# Altium schematic sheet SHIELD_TRF7960A.SchDoc(SHIELD_TRF7960A)
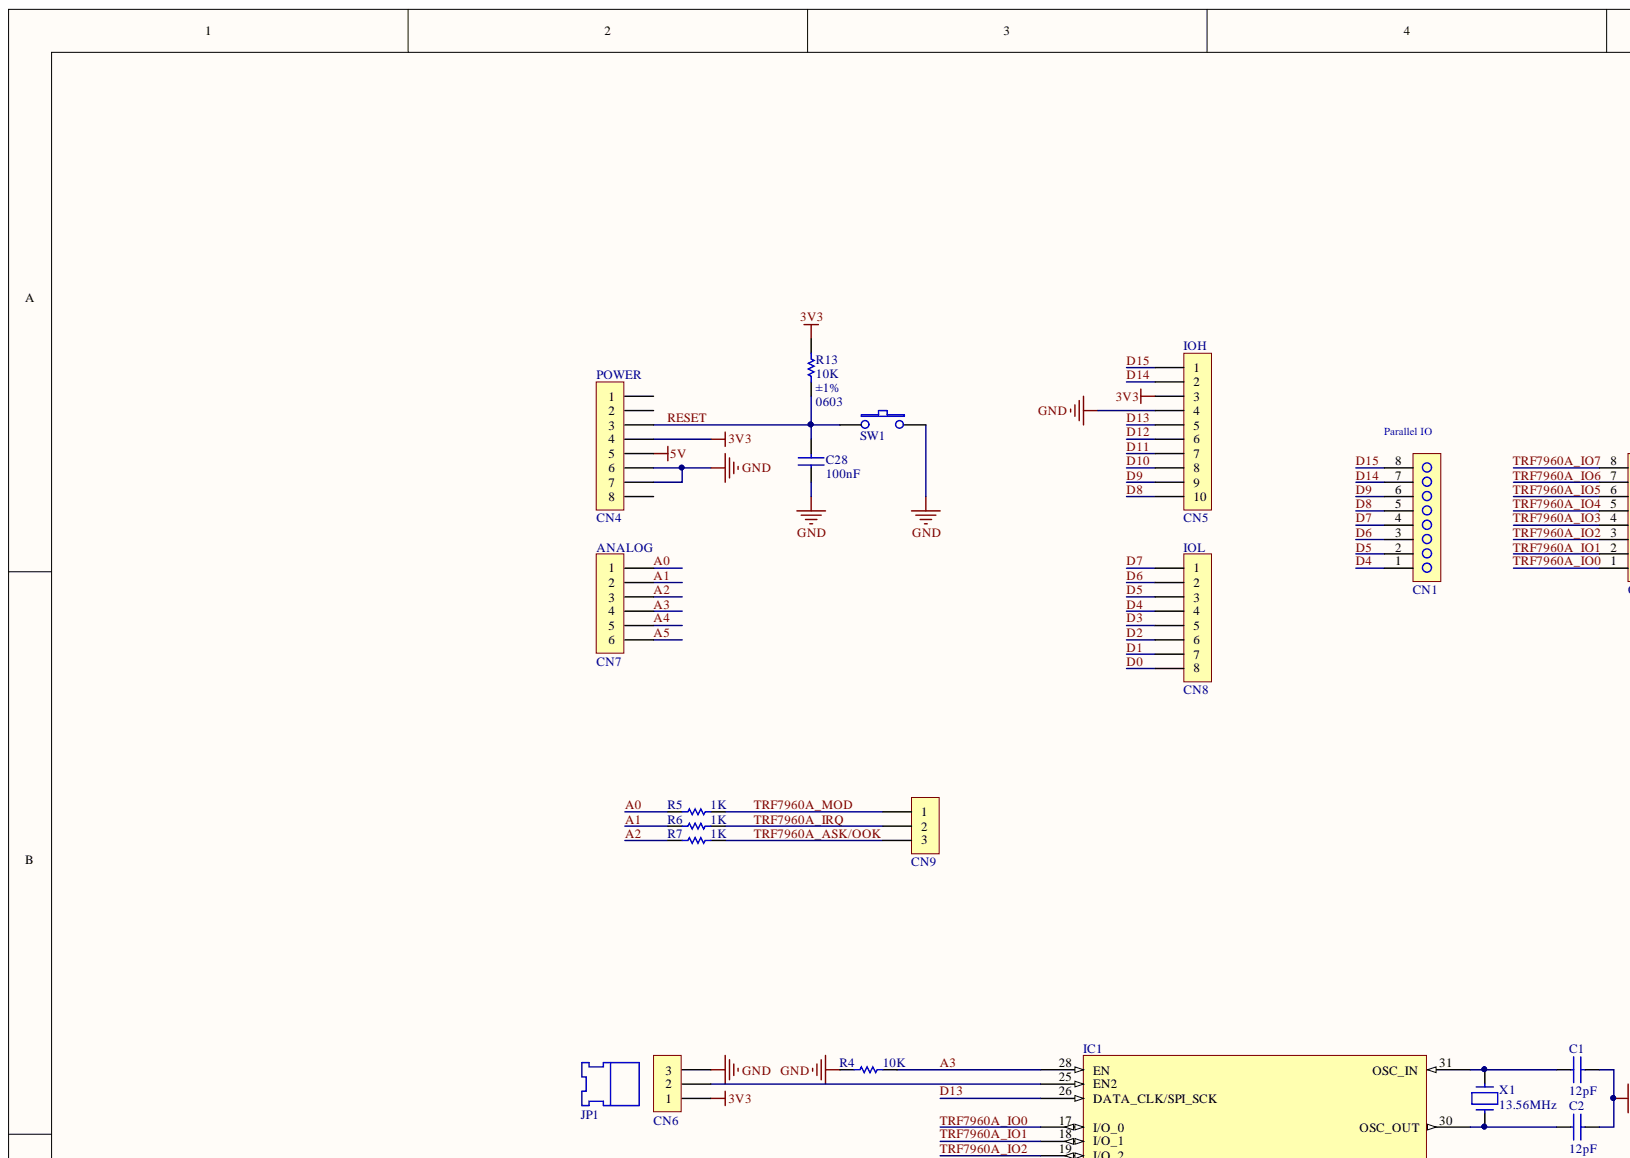
[diagram p0: SHIELD_TRF7960A.SchDoc, top left]
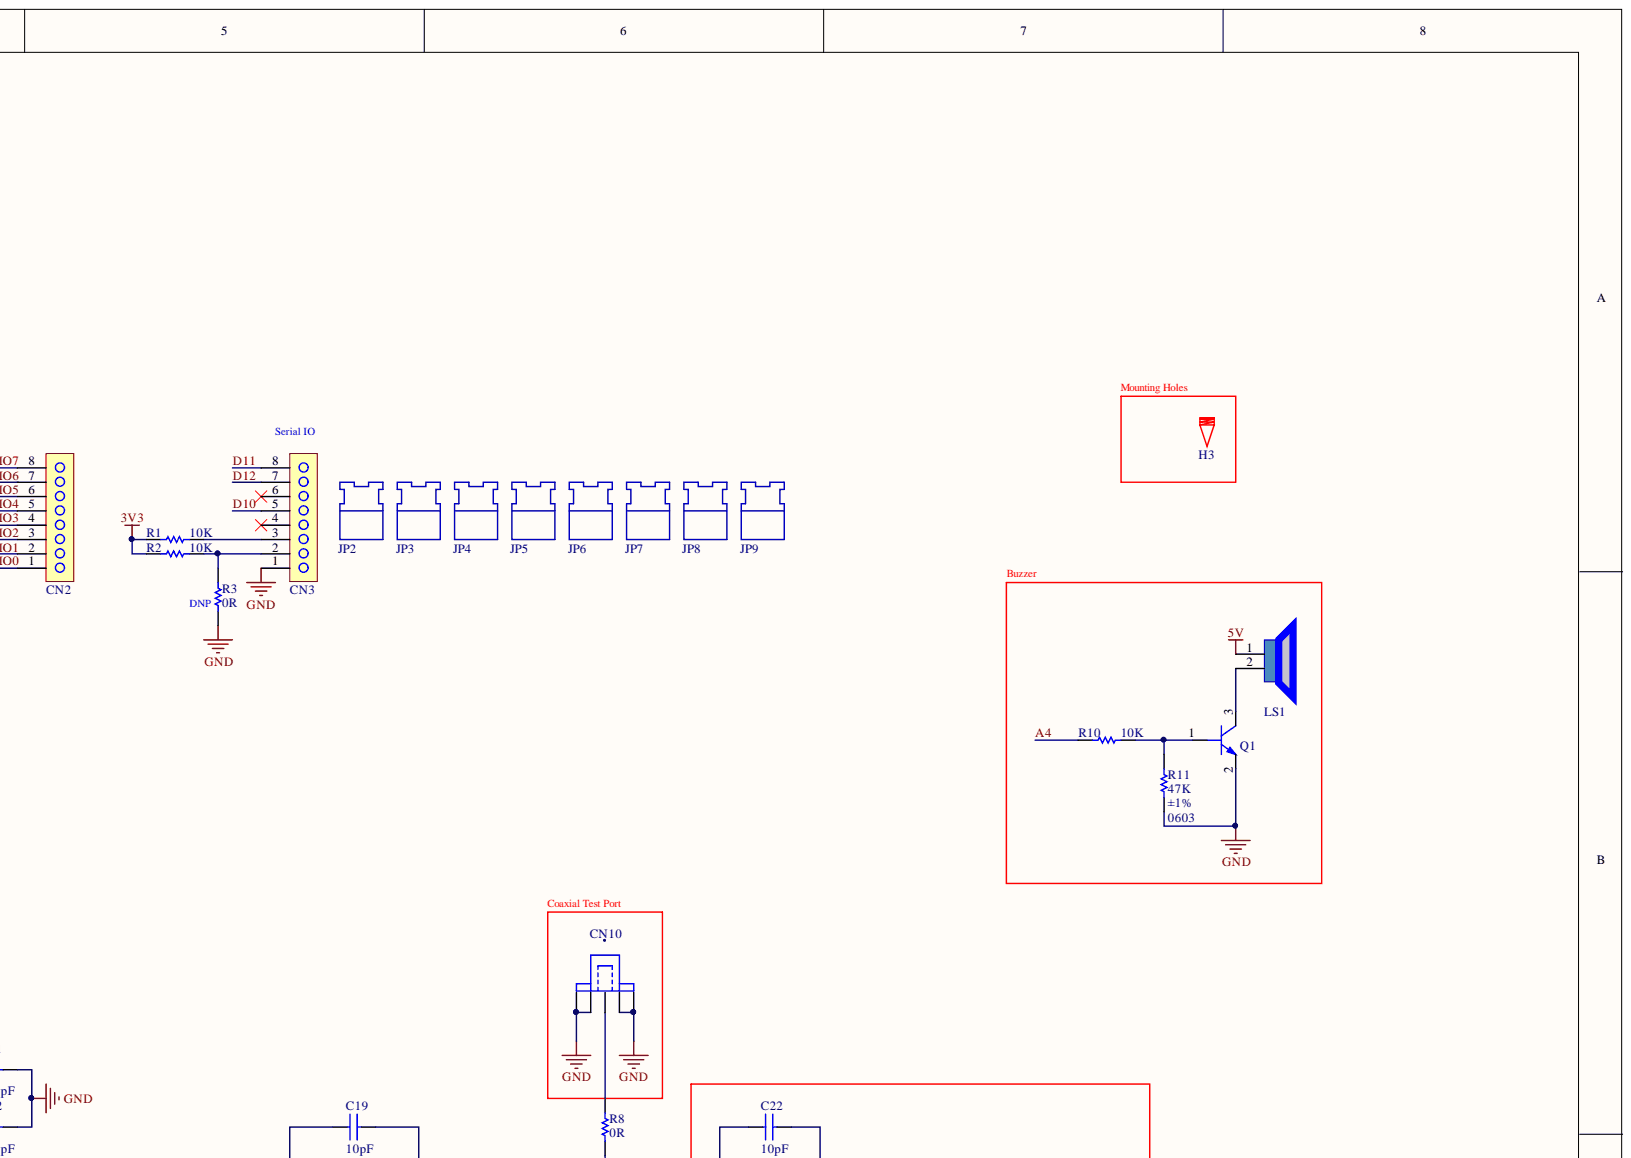
[diagram p1: SHIELD_TRF7960A.SchDoc, top right]
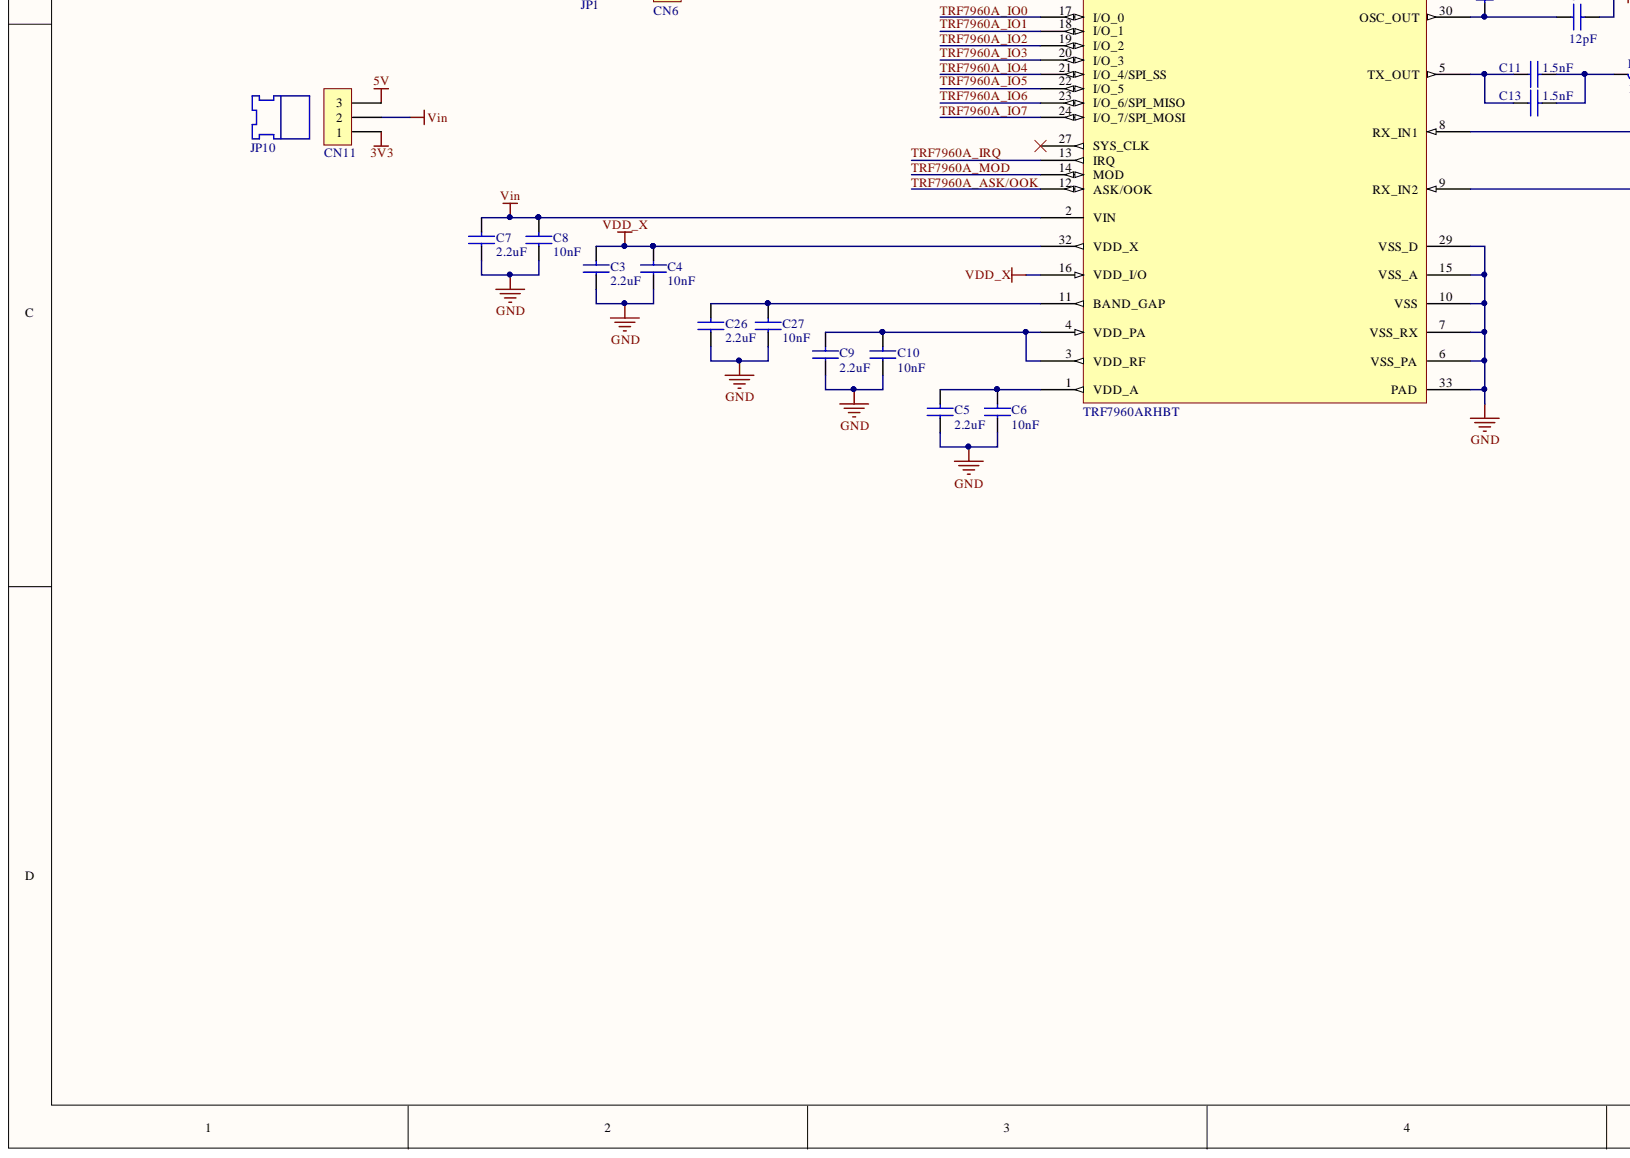
[diagram p2: SHIELD_TRF7960A.SchDoc, bottom left]
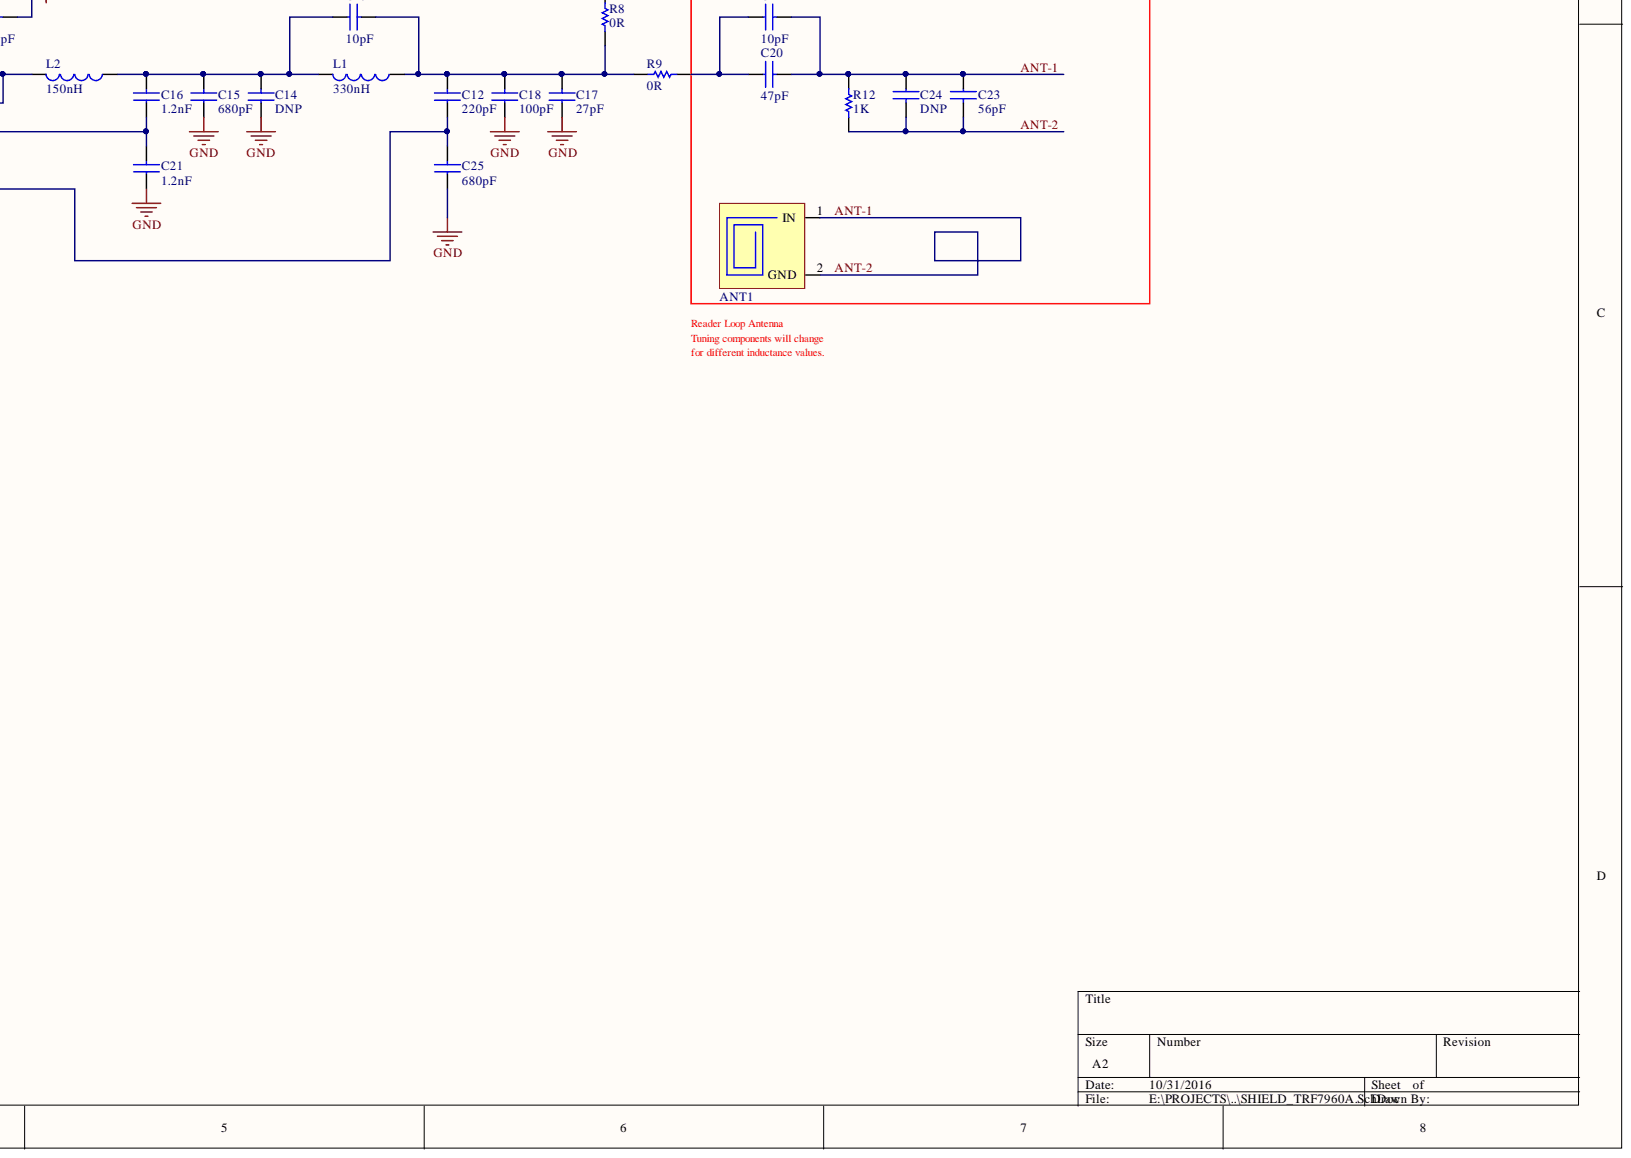
[diagram p3: SHIELD_TRF7960A.SchDoc, bottom right]

## Parts
ANT1  ANTENNA_LOOP  [ANTENNA_LOOP]  (value not drawn)
C1  value 12pF  Capacitance: 12pF  [CAPC1608X90N_EIA0603]  (hidden comment: CAP_NP)
C2  value 12pF  Capacitance: 12pF  [CAPC1608X90N_EIA0603]  (hidden comment: CAP_NP)
C3  value 2.2uF  [CAPC1608X90N_EIA0603]  (hidden comment: CAP_NP)
C4  value 10nF  Capacitance: 10nF  [CAPC1608X90N_EIA0603]  (hidden comment: CAP_NP)
C5  value 2.2uF  [CAPC1608X90N_EIA0603]  (hidden comment: CAP_NP)
C6  value 10nF  Capacitance: 10nF  [CAPC1608X90N_EIA0603]  (hidden comment: CAP_NP)
C7  value 2.2uF  [CAPC1608X90N_EIA0603]  (hidden comment: CAP_NP)
C8  value 10nF  Capacitance: 10nF  [CAPC1608X90N_EIA0603]  (hidden comment: CAP_NP)
C9  value 2.2uF  [CAPC1608X90N_EIA0603]  (hidden comment: CAP_NP)
C10  value 10nF  Capacitance: 10nF  [CAPC1608X90N_EIA0603]  (hidden comment: CAP_NP)
C11  value 1.5nF  Capacitance: 1.5nF  [CAPC1608X90N_EIA0603]  (hidden comment: CAP_NP)
C12  value 220pF  Capacitance: 220pF  [CAPC1608X90N_EIA0603]  (hidden comment: CAP_NP)
C13  value 1.5nF  Capacitance: 1.5nF  [CAPC1608X90N_EIA0603]  (hidden comment: CAP_NP)
C14  value DNP  Capacitance: DNP  [CAPC1608X90N_EIA0603]  (hidden comment: CAP_NP)
C15  value 680pF  Capacitance: 680pF  [CAPC1608X90N_EIA0603]  (hidden comment: CAP_NP)
C16  value 1.2nF  Capacitance: 1.2nF  [CAPC1608X90N_EIA0603]  (hidden comment: CAP_NP)
C17  value 27pF  Capacitance: 27pF  [CAPC1608X90N_EIA0603]  (hidden comment: CAP_NP)
C18  value 100pF  Capacitance: 100pF  [CAPC1608X90N_EIA0603]  (hidden comment: CAP_NP)
C19  value 10pF  Capacitance: 10pF  [CAPC1608X90N_EIA0603]  (hidden comment: CAP_NP)
C20  value 47pF  Capacitance: 47pF  [CAPC1608X90N_EIA0603]  (hidden comment: CAP_NP)
C21  value 1.2nF  Capacitance: 1.2nF  [CAPC1608X90N_EIA0603]  (hidden comment: CAP_NP)
C22  value 10pF  Capacitance: 10pF  [CAPC1608X90N_EIA0603]  (hidden comment: CAP_NP)
C23  value 56pF  Capacitance: 56pF  [CAPC1608X90N_EIA0603]  (hidden comment: CAP_NP)
C24  value DNP  Capacitance: DNP  [CAPC1608X90N_EIA0603]  (hidden comment: CAP_NP)
C25  value 680pF  Capacitance: 680pF  [CAPC1608X90N_EIA0603]  (hidden comment: CAP_NP)
C26  value 2.2uF  [CAPC1608X90N_EIA0603]  (hidden comment: CAP_NP)
C27  value 10nF  Capacitance: 10nF  [CAPC1608X90N_EIA0603]  (hidden comment: CAP_NP)
C28  value 100nF  Capacitance: 100nF  [CAPC1608X90N_EIA0603]  (hidden comment: CAP_NP)
CN1  HDRV_1X8  [HDRV8W64P254_1X8_2032X254X600P]  (value not drawn)
CN2  HDRV_1X8  [HDRV8W64P254_1X8_2032X254X600P]  (value not drawn)
CN3  HDRV_1X8  [HDRV8W64P254_1X8_2032X254X600P]  (value not drawn)
CN4  HDR_1X8  [HDRFV8W70P254_1X8_2032X254X1118P]  (value not drawn)
CN5  HDR_1X10  [HDRFV10W70P254_1X10_2540X254X1118P]  (value not drawn)
CN6  HDR_1X3  [HDRV3W64P254_1X3_762X254X600P]  (value not drawn)
CN7  HDR_1X6  [HDRFV6W70P254_1X6_1524X254X1118P]  (value not drawn)
CN8  HDR_1X8  [HDRFV8W70P254_1X8_2032X254X1118P]  (value not drawn)
CN9  HDR_1X3  [HDRV3W64P254_1X3_762X254X600P]  (value not drawn)
CN10  SMA  [EMERSON_142-0701-201]  (value not drawn)
CN11  HDR_1X3  [HDRV3W64P254_1X3_762X254X600P]  (value not drawn)
H3  HOLES  [HOLE_125MIL]  (value not drawn)
IC1  TRF7960A  Manufacturer Part Number: TRF7960ARHBT  [QFN50P350X500X90_HS-33N]
JP1  JUMPER  [JUMPER254P]  (value not drawn)
JP2  JUMPER  [JUMPER254P]  (value not drawn)
JP3  JUMPER  [JUMPER254P]  (value not drawn)
JP4  JUMPER  [JUMPER254P]  (value not drawn)
JP5  JUMPER  [JUMPER254P]  (value not drawn)
JP6  JUMPER  [JUMPER254P]  (value not drawn)
JP7  JUMPER  [JUMPER254P]  (value not drawn)
JP8  JUMPER  [JUMPER254P]  (value not drawn)
JP9  JUMPER  [JUMPER254P]  (value not drawn)
JP10  JUMPER  [JUMPER254P]  (value not drawn)
L1  value 330nH  Inductance: 330nH  [INDC2115X13N_EIA0805]  (hidden comment: INDUCTOR)
L2  value 150nH  Inductance: 150nH  [INDC2115X13N_EIA0805]  (hidden comment: INDUCTOR)
LS1  BUZZER  [BUZZER_12MM]  (value not drawn)
Q1  BC817  [SOT95P230X110-3N_SOT-23]  (value not drawn)
R1  value 10K  Resistance (Ohm): 10K  [RESC1608X50N_EIA0603]  (hidden comment: RES)
R2  value 10K  Resistance (Ohm): 10K  [RESC1608X50N_EIA0603]  (hidden comment: RES)
R3  value 0R  [RESC1608X50N_EIA0603]  (hidden comment: RES)
R4  value 10K  Resistance (Ohm): 10K  [RESC1608X50N_EIA0603]  (hidden comment: RES)
R5  value 1K  Resistance (Ohm): 1K  [RESC1608X50N_EIA0603]  (hidden comment: RES)
R6  value 1K  Resistance (Ohm): 1K  [RESC1608X50N_EIA0603]  (hidden comment: RES)
R7  value 1K  Resistance (Ohm): 1K  [RESC1608X50N_EIA0603]  (hidden comment: RES)
R8  value 0R  [RESC1608X50N_EIA0603]  (hidden comment: RES)
R9  value 0R  [RESC1608X50N_EIA0603]  (hidden comment: RES)
R10  value 10K  Resistance (Ohm): 10K  [RESC1608X50N_EIA0603]  (hidden comment: RES)
R11  value 47K  Package / Case: 0603  Resistance (Ohm): 47K  Tolerance: ±1%  [RESC1608X50N_EIA0603]  (hidden comment: RES)
R12  value 1K  Resistance (Ohm): 1K  [RESC1608X50N_EIA0603]  (hidden comment: RES)
R13  value 10K  Package / Case: 0603  Resistance (Ohm): 10K  Tolerance: ±1%  [RESC1608X50N_EIA0603]  (hidden comment: RES)
SW1  SWITCH_TACTILE_2PIN  [C&K_RS-282G05A3-SM_RT]  (value not drawn)
X1  value 13.56MHz  [HC-49/US]  (hidden comment: CRYSTAL)

## Nets
3V3: CN4.4 CN5.3 CN6.1 CN11.1 R1.1 R2.1 R13.1
5V: CN4.5 CN11.3 LS1.1
GND: C1.2 C2.2 C3.1 C4.1 C5.1 C6.1 C7.1 C8.1 C9.1 C10.1 C14.1 C15.1 C17.1 C18.1 C21.1 C25.1 C26.1 C27.1 C28.1 CN3.1 CN4.6 CN4.7 CN5.4 CN6.3 CN10.2 CN10.3 CN10.4 CN10.5 IC1.6(VSS_PA) IC1.7(VSS_RX) IC1.10(VSS) IC1.15(VSS_A) IC1.29(VSS_D) IC1.33(PAD) Q1.2 R3.2 R4.1 R11.2 SW1.2
VDD_X: C3.2 C4.2 IC1.16(VDD_I/O) IC1.32(VDD_X)
VIN: C7.2 C8.2 CN11.2 IC1.2(VIN)
A0: CN7.1 R5.1
A1: CN7.2 R6.1
A2: CN7.3 R7.1
A3: CN7.4 IC1.28(EN) R4.2
A4: CN7.5 R10.1
A5: CN7.6
ANT-1: ANT1.1 ANT1.2 C20.2 C22.2 C23.1 C23.2 C24.1 C24.2 R12.1 R12.2
D0: CN8.8
D1: CN8.7
D2: CN8.6
D3: CN8.5
D4: CN1.1 CN8.4
D5: CN1.2 CN8.3
D6: CN1.3 CN8.2
D7: CN1.4 CN8.1
D8: CN1.5 CN5.10
D9: CN1.6 CN5.9
D10: CN3.5 CN5.8
D11: CN3.8 CN5.7
D12: CN3.7 CN5.6
D13: CN5.5 IC1.26(DATA_CLK/SPI_SCK)
D14: CN1.7 CN5.2
D15: CN1.8 CN5.1
RESET: C28.2 CN4.3 R13.2 SW1.1
TRF7960A_ASK/OOK: CN9.3 IC1.12(ASK/OOK) R7.2
TRF7960A_IO0: CN2.1 IC1.17(I/O_0)
TRF7960A_IO1: CN2.2 IC1.18(I/O_1)
TRF7960A_IO2: CN2.3 IC1.19(I/O_2)
TRF7960A_IO3: CN2.4 IC1.20(I/O_3)
TRF7960A_IO4: CN2.5 IC1.21(I/O_4/SPI_SS)
TRF7960A_IO5: CN2.6 IC1.22(I/O_5)
TRF7960A_IO6: CN2.7 IC1.23(I/O_6/SPI_MISO)
TRF7960A_IO7: CN2.8 IC1.24(I/O_7/SPI_MOSI)
TRF7960A_IRQ: CN9.2 IC1.13(IRQ) R6.2
TRF7960A_MOD: CN9.1 IC1.14(MOD) R5.2
NetC1_1: C1.1 IC1.31(OSC_IN) X1.1
NetC2_1: C2.1 IC1.30(OSC_OUT) X1.2
NetC5_2: C5.2 C6.2 IC1.1(VDD_A)
NetC9_2: C9.2 C10.2 IC1.3(VDD_RF) IC1.4(VDD_PA)
NetC11_1: C11.1 C13.1 IC1.5(TX_OUT)
NetC11_2: C11.2 C13.2 L2.2
NetC12_1: C12.1 C25.2 IC1.9(RX_IN2)
NetC12_2: C12.2 C17.2 C18.2 C19.2 L1.1 R8.1 R9.1
NetC14_2: C14.2 C15.2 C16.2 C19.1 L1.2 L2.1
NetC16_1: C16.1 C21.2 IC1.8(RX_IN1)
NetC20_1: C20.1 C22.1 R9.2
NetC26_2: C26.2 C27.2 IC1.11(BAND_GAP)
NetCN3_2: CN3.2 R2.2 R3.1
NetCN3_3: CN3.3 R1.2
NetCN6_2: CN6.2 IC1.25(EN2)
NetCN10_1: CN10.1 R8.2
NetLS1_2: LS1.2 Q1.3
NetQ1_1: Q1.1 R10.2 R11.1

Not connected: CN3 4 6; CN4 1 2 8; IC1 27(SYS_CLK)

## Library data of the parts
ANT1 = SMSC00004: ANTENNA LOOP; Database Table Name: Misc; Component Kind: Standard; Library Name: ComponentDatabase.DbLib; Part Number: SMSC00004; Value: ANTENNA
C1 C2 = SCAP00200035: CAP CER 12PF 50V NP0 0603; Manufacturer: Murata Electronics North America; Manufacturer Part Number: GRM1885C1H120JA01D; Cost x1 ($): 0.10000; Cost x10 ($): 0.04100; Cost x100 ($): 0.01870; Cost x1000 ($): 0.01020; Database Table Name: Capacitor; Distrubutor: Digikey; Lead Time: 19 Weeks; Temperature Coefficient: C0G, NP0; Tolerance: ±5%; Type: Multi Layer Ceramic Capacitor  (MLCC); Category: Capacitors; Component Kind: Standard; Distributor Link: http://www.digikey.com/product-detail/en/murata-electronics-north-america/GRM1885C1H120JA01D/490-1405-1-ND/587644; Distributor Part Number: 490-1405-1-ND; Family: Ceramic Capacitors; Library Name: ComponentDatabase.DbLib; Moisture Sensitivity Level (MSL): 1 (Unlimited); Mounting Type: Surface Mount, MLCC; Operating Temperature: -55°C ~ 125°C; Package / Case: 0603; Part Number: SCAP00200035; Quantity: 0; ROHS: YES; Size / Dimension: 0.063" L x 0.031" W (1.60mm x 0.80mm); Voltage - Rated: 50V
C3 C5 C7 C9 C26 = SCAP00200098: CAP CER 2.2UF 6.3V X5R 0603; Manufacturer: Murata Electronics North America; Manufacturer Part Number: GRM188R60J225KE19D; Capacitance: 2.2µF; Cost x1 ($): 0.13000; Cost x10 ($): 0.08800; Cost x100 ($): 0.04180; Cost x1000 ($): 0.02420; Database Table Name: Capacitor; Distrubutor: Digikey; Lead Time: 19 Weeks; Tolerance: ±10%; Type: Multi Layer Ceramic Capacitor  (MLCC); Category: Capacitors; Component Kind: Standard; Distributor Link: http://www.digikey.com/product-detail/en/murata-electronics-north-america/GRM188R60J225KE19D/490-1552-1-ND/587757; Distributor Part Number: 490-1552-1-ND; Family: Ceramic Capacitors; Library Name: ComponentDatabase.DbLib; Moisture Sensitivity Level (MSL): 1 (Unlimited); Mounting Type: Surface Mount, MLCC; Operating Temperature: -55°C ~ 85°C; Package / Case: 0603; Part Number: SCAP00200098; Quantity: 0; ROHS: YES; Size / Dimension: 0.063" L x 0.031" W (1.60mm x 0.80mm); Temperature Coefficient: X5R; Voltage - Rated: 6.3V
C4 C6 C8 C10 C27 = SCAP00200083: CAP CER 10000PF 50V X7R 0603; Manufacturer: Murata Electronics North America; Manufacturer Part Number: GRM188R71H103KA01D; Cost x1 ($): 0.10000; Cost x10 ($): 0.01900; Cost x100 ($): 0.00850; Cost x1000 ($): 0.00465; Database Table Name: Capacitor; Distrubutor: Digikey; Lead Time: 19 Weeks; Tolerance: ±10%; Type: Multi Layer Ceramic Capacitor  (MLCC); Category: Capacitors; Component Kind: Standard; Distributor Link: http://www.digikey.com/product-detail/en/murata-electronics-north-america/GRM188R71H103KA01D/490-1512-1-ND/587862; Distributor Part Number: 490-1512-1-ND; Family: Ceramic Capacitors; Library Name: ComponentDatabase.DbLib; Moisture Sensitivity Level (MSL): 1 (Unlimited); Mounting Type: Surface Mount, MLCC; Operating Temperature: -55°C ~ 125°C; Package / Case: 0603; Part Number: SCAP00200083; Quantity: 0; ROHS: YES; Size / Dimension: 0.063" L x 0.031" W (1.60mm x 0.80mm); Temperature Coefficient: X7R; Voltage - Rated: 50V
C11 C13 = SCAP00200075: CAP CER 1500PF 50V X7R 0603; Manufacturer: Murata Electronics North America; Manufacturer Part Number: GRM188R71H152KA01D; Cost x1 ($): 0.10000; Cost x10 ($): 0.03500; Cost x100 ($): 0.01600; Cost x1000 ($): 0.00873; Database Table Name: Capacitor; Distrubutor: Digikey; Lead Time: 19 Weeks; Tolerance: ±10%; Type: Multi Layer Ceramic Capacitor  (MLCC); Category: Capacitors; Component Kind: Standard; Distributor Link: http://www.digikey.com/product-detail/en/murata-electronics-north-america/GRM188R71H152KA01D/490-1498-1-ND/587878; Distributor Part Number: 490-1498-1-ND; Family: Ceramic Capacitors; Library Name: ComponentDatabase.DbLib; Moisture Sensitivity Level (MSL): 1 (Unlimited); Mounting Type: SMD; Operating Temperature: -55°C ~ 125°C; Package / Case: 0603; Part Number: SCAP00200075; Quantity: 0; ROHS: YES; Size / Dimension: 0.063" L x 0.031" W (1.60mm x 0.80mm); Temperature Coefficient: X7R; Voltage - Rated: 50V
C12 = SCAP00200065: CAP CER 220PF 50V NP0 0603; Manufacturer: Murata Electronics North America; Manufacturer Part Number: GRM1885C1H221JA01D; Cost x1 ($): 0.10000; Cost x10 ($): 0.04100; Cost x100 ($): 0.01870; Cost x1000 ($): 0.01020; Database Table Name: Capacitor; Distrubutor: Digikey; Lead Time: 19 Weeks; Temperature Coefficient: C0G, NP0; Tolerance: ±5%; Type: Multi Layer Ceramic Capacitor  (MLCC); Category: Capacitors; Component Kind: Standard; Distributor Link: http://www.digikey.com/product-detail/en/murata-electronics-north-america/GRM1885C1H221JA01D/490-1435-1-ND/587673; Distributor Part Number: 490-1435-1-ND; Family: Ceramic Capacitors; Library Name: ComponentDatabase.DbLib; Moisture Sensitivity Level (MSL): 1 (Unlimited); Mounting Type: SMD; Operating Temperature: -55°C ~ 125°C; Package / Case: 0603; Part Number: SCAP00200065; Quantity: 0; ROHS: YES; Size / Dimension: 0.063" L x 0.031" W (1.60mm x 0.80mm); Voltage - Rated: 50V
C14 C24 = SCAP00200000: Database Table Name: Capacitor; Distrubutor: Digikey; Type: Multi Layer Ceramic Capacitor  (MLCC); Category: Capacitors; Component Kind: Standard; Family: Ceramic Capacitors; Library Name: ComponentDatabase.DbLib; Package / Case: 0603; Part Number: SCAP00200000; Quantity: 0; ROHS: YES
C15 C25 = SCAP00200071: CAP CER 680PF 50V NP0 0603; Manufacturer: Murata Electronics North America; Manufacturer Part Number: GRM1885C1H681JA01D; Cost x1 ($): 0.10000; Cost x10 ($): 0.06600; Cost x100 ($): 0.03040; Cost x1000 ($): 0.01659; Database Table Name: Capacitor; Distrubutor: Digikey; Lead Time: 17 Weeks; Temperature Coefficient: C0G, NP0; Tolerance: ±5%; Type: Multi Layer Ceramic Capacitor  (MLCC); Category: Capacitors; Component Kind: Standard; Distributor Link: http://www.digikey.com/product-detail/en/murata-electronics-north-america/GRM1885C1H681JA01D/490-1447-1-ND/587664; Distributor Part Number: 490-1447-1-ND; Family: Ceramic Capacitors; Library Name: ComponentDatabase.DbLib; Moisture Sensitivity Level (MSL): 1 (Unlimited); Mounting Type: SMD; Operating Temperature: -55°C ~ 125°C; Package / Case: 0603; Part Number: SCAP00200071; Quantity: 0; ROHS: YES; Size / Dimension: 0.063" L x 0.031" W (1.60mm x 0.80mm); Voltage - Rated: 50V
C16 C21 = SCAP00200074: CAP CER 1200PF 50V X7R 0603; Manufacturer: Murata Electronics North America; Manufacturer Part Number: GRM188R71H122KA01D; Cost x1 ($): 0.10000; Cost x10 ($): 0.05600; Cost x100 ($): 0.02590; Cost x1000 ($): 0.01410; Database Table Name: Capacitor; Distrubutor: Digikey; Lead Time: 19 Weeks; Tolerance: ±10%; Type: Multi Layer Ceramic Capacitor  (MLCC); Category: Capacitors; Component Kind: Standard; Distributor Link: http://www.digikey.com/product-detail/en/murata-electronics-north-america/GRM188R71H122KA01D/490-1496-1-ND/587853; Distributor Part Number: 490-1496-1-ND; Family: Ceramic Capacitors; Library Name: ComponentDatabase.DbLib; Moisture Sensitivity Level (MSL): 1 (Unlimited); Mounting Type: SMD; Operating Temperature: -55°C ~ 125°C; Package / Case: 0603; Part Number: SCAP00200074; Quantity: 0; ROHS: YES; Size / Dimension: 0.063" L x 0.031" W (1.60mm x 0.80mm); Temperature Coefficient: X7R; Voltage - Rated: 50V
C17 = SCAP00200043: CAP CER 27PF 50V NP0 0603; Manufacturer: Murata Electronics North America; Manufacturer Part Number: GRM1885C1H270JA01D; Cost x1 ($): 0.10000; Cost x10 ($): 0.04100; Cost x100 ($): 0.01870; Cost x1000 ($): 0.01020; Database Table Name: Capacitor; Distrubutor: Digikey; Lead Time: 19 Weeks; Temperature Coefficient: C0G, NP0; Tolerance: ±5%; Type: Multi Layer Ceramic Capacitor  (MLCC); Category: Capacitors; Component Kind: Standard; Distributor Link: http://www.digikey.com/product-detail/en/murata-electronics-north-america/GRM1885C1H270JA01D/490-1413-1-ND/587618; Distributor Part Number: 490-1413-1-ND; Family: Ceramic Capacitors; Library Name: ComponentDatabase.DbLib; Moisture Sensitivity Level (MSL): 1 (Unlimited); Mounting Type: SMD; Operating Temperature: -55°C ~ 125°C; Package / Case: 0603; Part Number: SCAP00200043; Quantity: 0; ROHS: YES; Size / Dimension: 0.063" L x 0.031" W (1.60mm x 0.80mm); Voltage - Rated: 50V
C18 = SCAP00200057: CAP CER 100PF 50V NP0 0603; Manufacturer: Murata Electronics North America; Manufacturer Part Number: GRM1885C1H101JA01D; Cost x1 ($): 0.10000; Cost x10 ($): 0.03100; Cost x100 ($): 0.01400; Cost x1000 ($): 0.00765; Database Table Name: Capacitor; Distrubutor: Digikey; Lead Time: 19 Weeks; Temperature Coefficient: C0G, NP0; Tolerance: ±5%; Type: Multi Layer Ceramic Capacitor  (MLCC); Category: Capacitors; Component Kind: Standard; Distributor Link: http://www.digikey.com/product-detail/en/murata-electronics-north-america/GRM1885C1H101JA01D/490-1427-1-ND/587633; Distributor Part Number: 490-1427-1-ND; Family: Ceramic Capacitors; Library Name: ComponentDatabase.DbLib; Moisture Sensitivity Level (MSL): 1 (Unlimited); Mounting Type: SMD; Operating Temperature: -55°C ~ 125°C; Package / Case: 0603; Part Number: SCAP00200057; Quantity: 0; ROHS: YES; Size / Dimension: 0.063" L x 0.031" W (1.60mm x 0.80mm); Voltage - Rated: 50V
C19 C22 = SCAP00200033: CAP CER 10PF 50V NP0 0603; Manufacturer: Murata Electronics North America; Manufacturer Part Number: GCM1885C1H100JA16D; Cost x1 ($): 0.10000; Cost x10 ($): 0.04200; Cost x100 ($): 0.01930; Cost x1000 ($): 0.01050; Database Table Name: Capacitor; Distrubutor: Digikey; Lead Time: 19 Weeks; Temperature Coefficient: C0G, NP0; Tolerance: ±5%; Type: Multi Layer Ceramic Capacitor  (MLCC); Category: Capacitors; Component Kind: Standard; Distributor Link: http://www.digikey.com/product-detail/en/murata-electronics-north-america/GCM1885C1H100JA16D/490-4766-1-ND/1641685; Distributor Part Number: 490-4766-1-ND; Family: Ceramic Capacitors; Library Name: ComponentDatabase.DbLib; Moisture Sensitivity Level (MSL): 1 (Unlimited); Mounting Type: SMD; Operating Temperature: -55°C ~ 125°C; Package / Case: 0603; Part Number: SCAP00200033; Quantity: 0; ROHS: YES; Size / Dimension: 0.063" L x 0.031" W (1.60mm x 0.80mm); Voltage - Rated: 50V
C20 = SCAP00200049: CAP CER 47PF 50V NP0 0603; Manufacturer: Murata Electronics North America; Manufacturer Part Number: GRM1885C1H470JA01D; Cost x1 ($): 0.10000; Cost x10 ($): 0.02900; Cost x100 ($): 0.01320; Cost x1000 ($): 0.00720; Database Table Name: Capacitor; Distrubutor: Digikey; Lead Time: 19 Weeks; Temperature Coefficient: C0G, NP0; Tolerance: ±5%; Type: Multi Layer Ceramic Capacitor  (MLCC); Category: Capacitors; Component Kind: Standard; Distributor Link: http://www.digikey.com/product-detail/en/murata-electronics-north-america/GRM1885C1H470JA01D/490-1419-1-ND/587616; Distributor Part Number: 490-1419-1-ND; Family: Ceramic Capacitors; Library Name: ComponentDatabase.DbLib; Moisture Sensitivity Level (MSL): 1 (Unlimited); Mounting Type: SMD; Operating Temperature: -55°C ~ 125°C; Package / Case: 0603; Part Number: SCAP00200049; Quantity: 0; ROHS: YES; Size / Dimension: 0.063" L x 0.031" W (1.60mm x 0.80mm); Voltage - Rated: 50V
C23 = SCAP00200051: CAP CER 56PF 50V NP0 0603; Manufacturer: Murata Electronics North America; Manufacturer Part Number: GRM1885C1H560JA01D; Cost x1 ($): 0.10000; Cost x10 ($): 0.04100; Cost x100 ($): 0.01870; Cost x1000 ($): 0.01020; Database Table Name: Capacitor; Distrubutor: Digikey; Lead Time: 19 Weeks; Temperature Coefficient: C0G, NP0; Tolerance: ±5%; Type: Multi Layer Ceramic Capacitor  (MLCC); Category: Capacitors; Component Kind: Standard; Distributor Link: http://www.digikey.com/product-detail/en/murata-electronics-north-america/GRM1885C1H560JA01D/490-1421-1-ND/587626; Distributor Part Number: 490-1421-1-ND; Family: Ceramic Capacitors; Library Name: ComponentDatabase.DbLib; Moisture Sensitivity Level (MSL): 1 (Unlimited); Mounting Type: SMD; Operating Temperature: -55°C ~ 125°C; Package / Case: 0603; Part Number: SCAP00200051; Quantity: 0; ROHS: YES; Size / Dimension: 0.063" L x 0.031" W (1.60mm x 0.80mm); Voltage - Rated: 50V
C28 = SCAP00200091: CAP CER 0.1UF 16V X7R 0603; Manufacturer: Murata Electronics North America; Manufacturer Part Number: GRM188R71C104KA01D; Cost x1 ($): 0.10000; Cost x10 ($): 0.01900; Cost x100 ($): 0.00860; Cost x1000 ($): 0.00468; Database Table Name: Capacitor; Distrubutor: Digikey; Lead Time: 11 Weeks; Tolerance: ±10%; Type: Multi Layer Ceramic Capacitor  (MLCC); Category: Capacitors; Component Kind: Standard; Distributor Link: http://www.digikey.com/product-detail/en/murata-electronics-north-america/GRM188R71C104KA01D/490-1532-1-ND/587771; Distributor Part Number: 490-1532-1-ND; Family: Ceramic Capacitors; Library Name: ComponentDatabase.DbLib; Moisture Sensitivity Level (MSL): 1 (Unlimited); Mounting Type: Surface Mount, MLCC; Operating Temperature: -55°C ~ 125°C; Package / Case: 0603; Part Number: SCAP00200091; Quantity: 0; ROHS: YES; Size / Dimension: 0.063" L x 0.031" W (1.60mm x 0.80mm); Temperature Coefficient: X7R; Voltage - Rated: 16V
CN1-CN3 = SCON00132: CONN HEADER 8POS .100 VERT TIN; Manufacturer: Harwin Inc.; Manufacturer Part Number: M20-9990846; Connector Type: Male Pin; Cost x1 ($): 0.23000; Cost x10 ($): 0.20400; Cost x100 ($): 0.16800; Cost x1000 ($): 0.11400; Database Table Name: Connector; Distrubutor: Digikey; Lead Time: 6 Weeks; Pitch: 2.54mm; Value: HDR_M_1X8_254; Category: Connectors, Interconnects; Component Kind: Standard; Contact Type: Header, Unshrouded; Datasheet Link: http://www.digikey.com/product-detail/en/harwin-inc/M20-9990846/952-2271-ND/3728235; Distributor Part Number: 952-2271-ND; Family: Rectangular Connectors - Headers, Male Pins; Library Name: ComponentDatabase.DbLib; Moisture Sensitivity Level (MSL): 1 (Unlimited); Mounting Type: Through Hole; Part Number: SCON00132; Part Status: Active; Quantity: 0; ROHS: YES
CN4 CN8 = SCON00125: CONN ARDUINO HEADER .0100" 8POS; Manufacturer Part Number: PRT-09279; Connector Type: Stackable Header; Cost x1 ($): 0.50; Cost x100 ($): 0.48; Cost x1000 ($): 0.45; Database Table Name: Connector; Distrubutor: Sparkfun; Pitch: 2.54mm; Category: Connectors, Interconnects; Component Kind: Standard; Contact Type: Female Socket; Cost x10 ($): 0.50; Datasheet Link: https://www.sparkfun.com/products/9279; Distributor Part Number: PRT-09279; Family: Rectangular Connectors - Headers, Receptacles, Female Sockets; Library Name: ComponentDatabase.DbLib; Manufacturer: Sparkfun; Mounting Type: Through Hole; Part Number: SCON00125; Quantity: 10; ROHS: YES; Value: HDR_1X8
CN5 = SCON00126: CONN ARDUINO HEADER .0100" 10POS; Manufacturer Part Number: PRT-11376; Connector Type: Stackable Header; Cost x1 ($): 0.50; Cost x100 ($): 0.48; Cost x1000 ($): 0.45; Database Table Name: Connector; Distrubutor: Sparkfun; Pitch: 2.54mm; Category: Connectors, Interconnects; Component Kind: Standard; Contact Type: Female Socket; Cost x10 ($): 0.50; Datasheet Link: https://www.sparkfun.com/products/11376; Distributor Part Number: PRT-11376; Family: Rectangular Connectors - Headers, Receptacles, Female Sockets; Library Name: ComponentDatabase.DbLib; Manufacturer: Sparkfun; Mounting Type: Through Hole; Part Number: SCON00126; Quantity: 10; ROHS: YES; Value: HDR_1X10
CN6 CN9 CN11 = SCON00131: CONN HEADER MALE 3PS .1" DL TIN; Manufacturer: Wurth Electronics Inc; Manufacturer Part Number: 61300311121; Connector Type: Male Pin; Cost x1 ($): 0.13000; Cost x10 ($): 0.10600; Cost x100 ($): 0.06700; Cost x1000 ($): 0.06100; Database Table Name: Connector; Distrubutor: Digikey; Pitch: 2.54mm; Category: Connectors, Interconnects; Component Kind: Standard; Contact Type: Header, Unshrouded; Datasheet Link: http://www.digikey.com/product-detail/en/wurth-electronics-inc/61300311121/732-5316-ND/4846825; Distributor Part Number: 732-5316-ND; Family: Rectangular Connectors - Headers, Male Pins; Library Name: ComponentDatabase.DbLib; Mounting Type: Through Hole; Part Number: SCON00131; Quantity: 0; ROHS: YES; Value: HDR_1X3
CN7 = SCON00124: CONN ARDUINO HEADER .0100" 6POS; Manufacturer Part Number: PRT-09280; Connector Type: Stackable Header; Cost x1 ($): 0.50; Cost x100 ($): 0.48; Cost x1000 ($): 0.45; Database Table Name: Connector; Distrubutor: Sparkfun; Pitch: 2.54mm; Category: Connectors, Interconnects; Component Kind: Standard; Contact Type: Female Socket; Cost x10 ($): 0.50; Datasheet Link: https://www.sparkfun.com/products/9280; Distributor Part Number: PRT-09280; Family: Rectangular Connectors - Headers, Receptacles, Female Sockets; Library Name: ComponentDatabase.DbLib; Manufacturer: Sparkfun; Mounting Type: Through Hole; Part Number: SCON00124; Quantity: 10; ROHS: YES; Value: HDR_1X6
CN10 = SCON00009: CONN SMA JACK STR 50 OHM PCB; Manufacturer: Emerson Network Power Connectivity Johnson; Manufacturer Part Number: 142-0701-201; Connector Type: SMA - Jack, Female Socket; Cost x1 ($): 4.68000; Cost x10 ($): 4.16500; Cost x100 ($): 3.06000; Cost x1000 ($): 2.12500; Database Table Name: Connector; Distrubutor: Digikey; Value: SMA_FEMALE_STRAIGHT; Category: Connectors, Interconnects; Component Kind: Standard; Datasheet Link: http://www.digikey.com/product-detail/en/142-0701-201/J500-ND/35274; Distributor Part Number: J500-ND; Family: Coaxial Connectors (RF); Library Name: ComponentDatabase.DbLib; Mounting Type: Through Hole; Packaging: Bulk; Part Number: SCON00009; Quantity: 0; ROHS: YES
H3 = SMSC00005: Database Table Name: Misc; Value: HOLE_125MIL; Component Kind: Standard; Description: Holes; Library Name: ComponentDatabase.DbLib; Part Number: SMSC00005
IC1 = SIC00130: IC RFID AFE 13.56MHZ 32QFN; Manufacturer: Texas Instruments; Cost x1 ($): 7.40000; Cost x10 ($): 6.67000; Cost x100 ($): 5.51000; Cost x1000 ($): 4.20500; Distrubutor: Digikey; Protocol: SPI; Vin: 2.7 V ~ 5.5 V; Category: Integrated Circuits (ICs); Component Kind: Standard; Database Table Name: IC; Distributor Link: http://www.digikey.com/product-detail/en/texas-instruments/TRF7960ARHBT/296-29381-2-ND/2697046; Distributor Part Number: 296-29381-2-ND; Family: RF/IF and RFID; Library Name: ComponentDatabase.DbLib; Operating Temperature: -40°C ~ 110°C; Package / Case: 32-VFQFN Exposed Pad; Part Number: SIC00130; Quantity: 10; ROHS: YES; Speed: 13.56MHz; Type: ISO 14443A/B, ISO 15693, ISO 18000-3, NFC, Felica; Value: TRF7960A
JP1-JP10 = SCON00007: SHUNT JUMPER .1" BLACK GOLD; Manufacturer: 3M; Manufacturer Part Number: 969102-0000-DA; Connector Type: Open Top; Cost x1 ($): 0.10000; Cost x10 ($): 0.09400; Cost x100 ($): 0.05800; Cost x1000 ($): 0.04200; Database Table Name: Connector; Distrubutor: Digikey; Pitch: 0.100" (2.54mm); Category: Connectors, Interconnects; Component Kind: Standard; Datasheet Link: http://www.digikey.com/product-detail/en/969102-0000-DA/3M9580-ND/2071621; Distributor Part Number: 3M9580-ND; Family: Shunts, Jumpers; Library Name: ComponentDatabase.DbLib; Mounting Type: Through Hole, Right Angle; Part Number: SCON00007; Quantity: 110; ROHS: YES; Value: JUMPER
L1 = SIND00005: FIXED IND 330NH 300MA 1.28 OHM; Manufacturer: Pulse Electronics Corporation; Manufacturer Part Number: PE-0805CM331JTT; Cost x1 ($): 0.40000; Cost x10 ($): 0.36000; Cost x100 ($): 0.26000; Cost x1000 ($): 0.18400; DC Resistance (DCR): 1.28 Ohm Max; Distrubutor: Digikey; Lead Time: 8 Weeks; Q @ Freq: 40 @ 100MHz; Tolerance: ±5%; Type: Wirewound; Category: Inductors, Coils, Chokes; Component Kind: Standard; Current Rating: 300mA; Database Table Name: Inductor; Distributor Link: http://www.digikey.com/product-detail/en/pulse-electronics-corporation/PE-0805CM331JTT/553-1045-1-ND/754464; Distributor Part Number: 553-1045-1-ND; Family: Fixed Inductors; Library Name: ComponentDatabase.DbLib; Moisture Sensitivity Level (MSL): 1 (Unlimited); Operating Temperature: -40°C ~ 125°C; Package / Case: 0805 (2012 Metric); Part Number: SIND00005; ROHS: YES
L2 = SIND00004: FIXED IND 150NH 400MA 670 MOHM; Manufacturer: Bourns Inc.; Manufacturer Part Number: CW201212-R15J; Cost x1 ($): 0.28000; Cost x10 ($): 0.25600; Cost x100 ($): 0.18460; Cost x1000 ($): 0.13064; Distrubutor: Digikey; Lead Time: 16 Weeks; Q @ Freq: 50 @ 250MHz; Tolerance: ±5%; Type: Wirewound; Category: Inductors, Coils, Chokes; Component Kind: Standard; Current Rating: 400mA; Database Table Name: Inductor; DC Resistance (DCR): 670 mOhm; Distributor Link: http://www.digikey.com/product-detail/en/bourns-inc/CW201212-R15J/CW201212-R15JCT-ND/3741341; Distributor Part Number: CW201212-R15JCT-ND; Family: Fixed Inductors; Library Name: ComponentDatabase.DbLib; Moisture Sensitivity Level (MSL): 1 (Unlimited); Operating Temperature: -40°C ~ 125°C; Package / Case: 0805 (2012 Metric); Part Number: SIND00004; ROHS: YES
LS1 = SAUD00001: AUDIO MAGNETIC INDICATOR 4-7V TH; Manufacturer: CUI Inc.; Manufacturer Part Number: CEM-1205C; Cost x1 ($): 2.51000; Cost x10 ($): 2.08200; Cost x100 ($): 1.54200; Cost x1000 ($): 1.11795; Distrubutor: Digikey; Frequency: 2.3kHz; Iin: 35mA; Lead Time: 13 Weeks; Voltage: 5VDC; Active: Active; Category: Audio Products; Component Kind: Standard; Database Table Name: Audio; Datasheet Link: http://www.digikey.com/product-detail/en/cui-inc/CEM-1205C/102-1124-ND/412383; Distributor Part Number: 102-1124-ND; Family: Buzzers; Library Name: ComponentDatabase.DbLib; Moisture Sensitivity Level (MSL): 1 (Unlimited); Part Number: SAUD00001; Quantity: 20; ROHS: YES; Size / Dimension: 12.0mm Dia x 10.0mm H; Type: Indicator, Internally Driven; Value: BUZZER
Q1 = STRANS00034: TRANS NPN 45V 0.5A SOT23; Manufacturer: NXP Semiconductors; Manufacturer Part Number: BC817-40,215; Cost x1 ($): 0.14000; Cost x10 ($): 0.12700; Cost x100 ($): 0.06930; Cost x1000 ($): 0.02905; Database Table Name: Transistor; Distrubutor: Digikey; Frequency - Transition: 100MHz; hFE (Min) @ Ic, Vce: 250 @ 100mA, 1V; Ic (Max): 500mA; Ic Cutoff (Max): 100nA (ICBO); Lead Time: 6 Weeks; Power - Max: 250mW; Value: BC817-40; Vce Saturation (Max) @ Ib, Ic: 700mV @ 50mA, 500mA; Category: Discrete Semiconductor Products; Component Kind: Standard; Datasheet Link: http://www.digikey.com/product-detail/en/nxp-semiconductors/BC817-40,215/568-1631-1-ND/763458; Distributor Part Number: 568-1631-1-ND; Family: Transistors (BJT) - Single; Library Name: ComponentDatabase.DbLib; Mounting Type: SMD; Package / Case: SOT-23-3, TO-236-3, SC-59; Part Number: STRANS00034; Quantity: 110; ROHS: YES; Transistor Type: NPN; Vce Breakdown (Max): 45V
R1 R2 R4 R10 R13 = SRES02000098: RES SMD 10K OHM 1% 1/10W 0603; Manufacturer: Yageo; Manufacturer Part Number: RC0603FR-0710KL; Cost x1 ($): 0.10000; Cost x10 ($): 0.01400; Cost x100 ($): 0.00570; Cost x1000 ($): 0.00257; Database Table Name: Resistor; Distrubutor: Digikey; Lead Time: 22 Weeks; Power (Watts): 0.1W, 1/10W; Category: Resistors; Component Kind: Standard; Distributor Link: http://www.digikey.com/product-detail/en/yageo/RC0603FR-0710KL/311-10.0KHRCT-ND/729827; Distributor Part Number: 311-10.0KHRCT-ND; Family: Chip Resistor - Surface Mount; Library Name: ComponentDatabase.DbLib; Part Number: SRES02000098; Quantity: 0; ROHS: YES
  R1 R2 R4 R10: Package / Case: 0603; Tolerance: ±1%
R3 R8 R9 = SRES02030001: RES SMD 0.0 OHM JUMPER 1/8W 0603; Database Table Name: Resistor; Distrubutor: Digikey; Power (Watts): 0.125W, 1/8W; Resistance (Ohm): 0; Category: Resistors; Component Kind: Standard; Family: Chip Resistor - Surface Mount; Library Name: ComponentDatabase.DbLib; Package / Case: 0603; Part Number: SRES02030001; ROHS: YES; Tolerance: 0
R5-R7 R12 = SRES02000074: RES SMD 1K OHM 1% 1/10W 0603; Manufacturer: Yageo; Manufacturer Part Number: RC0603FR-071KL; Cost x1 ($): 0.10000; Cost x10 ($): 0.01400; Cost x100 ($): 0.00570; Cost x1000 ($): 0.00257; Database Table Name: Resistor; Distrubutor: Digikey; Lead Time: 22 Weeks; Power (Watts): 0.1W, 1/10W; Category: Resistors; Component Kind: Standard; Distributor Link: http://www.digikey.com/product-detail/en/yageo/RC0603FR-071KL/311-1.00KHRCT-ND/729790; Distributor Part Number: 311-1.00KHRCT-ND; Family: Chip Resistor - Surface Mount; Library Name: ComponentDatabase.DbLib; Package / Case: 0603; Part Number: SRES02000074; Quantity: 0; ROHS: YES; Tolerance: ±1%
R11 = SRES02000114: RES SMD 47K OHM 1% 1/10W 0603; Manufacturer: Yageo; Manufacturer Part Number: RC0603FR-0747KL; Cost x1 ($): 0.10000; Cost x10 ($): 0.01400; Cost x100 ($): 0.00570; Cost x1000 ($): 0.00257; Database Table Name: Resistor; Distrubutor: Digikey; Lead Time: 22 Weeks; Power (Watts): 0.1W, 1/10W; Category: Resistors; Component Kind: Standard; Distributor Link: http://www.digikey.com/product-detail/en/yageo/RC0603FR-0747KL/311-47.0KHRCT-ND/730200; Distributor Part Number: 311-47.0KHRCT-ND; Family: Chip Resistor - Surface Mount; Library Name: ComponentDatabase.DbLib; Part Number: SRES02000114; Quantity: 0; ROHS: YES
SW1 = SSWI00022: SWITCH TACTILE SPST-NO 0.05A 12V; Manufacturer: C&K Components; Manufacturer Part Number: RS-282G05A3-SM RT; Cost x1 ($): 0.76000; Cost x10 ($): 0.72900; Cost x100 ($): 0.55000; Cost x1000 ($): 0.39875; Distrubutor: Digikey; Lead Time: 10 Weeks; Value: SWITCH_TACTILE_MICRO_2PIN; Voltage: 12VDC; Category: Switches; Circuit: SPST-NO; Component Kind: Standard; Current: 0.05A; Database Table Name: Switch; Datasheet Link: 0; Distributor Part Number: CKN10384CT-ND; Distrubutor Link: http://www.digikey.com/product-detail/en/c-k-components/RS-282G05A3-SM-RT/CKN10384CT-ND/2747203; Family: Tactile Switches; Library Name: ComponentDatabase.DbLib; Moisture Sensitivity Level (MSL): 1 (Unlimited); Package: SMD; Part Number: SSWI00022; ROHS: YES
X1 = SCRY00014: CRYSTAL 13.5600MHZ 12PF T/H; Manufacturer: TXC CORPORATION; Manufacturer Part Number: 9B-13.560MAAE-B; Cost x1 ($): 0.36000; Cost x10 ($): 0.30000; Cost x100 ($): 0.24000; Cost x1000 ($): 0.19200; Distrubutor: Digikey; ESR: 40 Ohm; Frequency Tolerance: ±30ppm; Lead Time: 13 Weeks; Component Kind: Standard; Database Table Name: Crystal; Datasheet Link: http://www.digikey.com/product-detail/en/9B-13.560MAAE-B/887-2013-ND/3522046; Distributor Part Number: 887-2013-ND; Library Name: ComponentDatabase.DbLib; Load Capacitance: 12pF; Mounting Type: Through Hole; Package / Case: HC49/US; Part Number: SCRY00014; Quantity: 10; ROHS: YES; Type: MHz Crystal
